# Altium schematic sheet Microcontroller.SchDoc(Microcontroller)
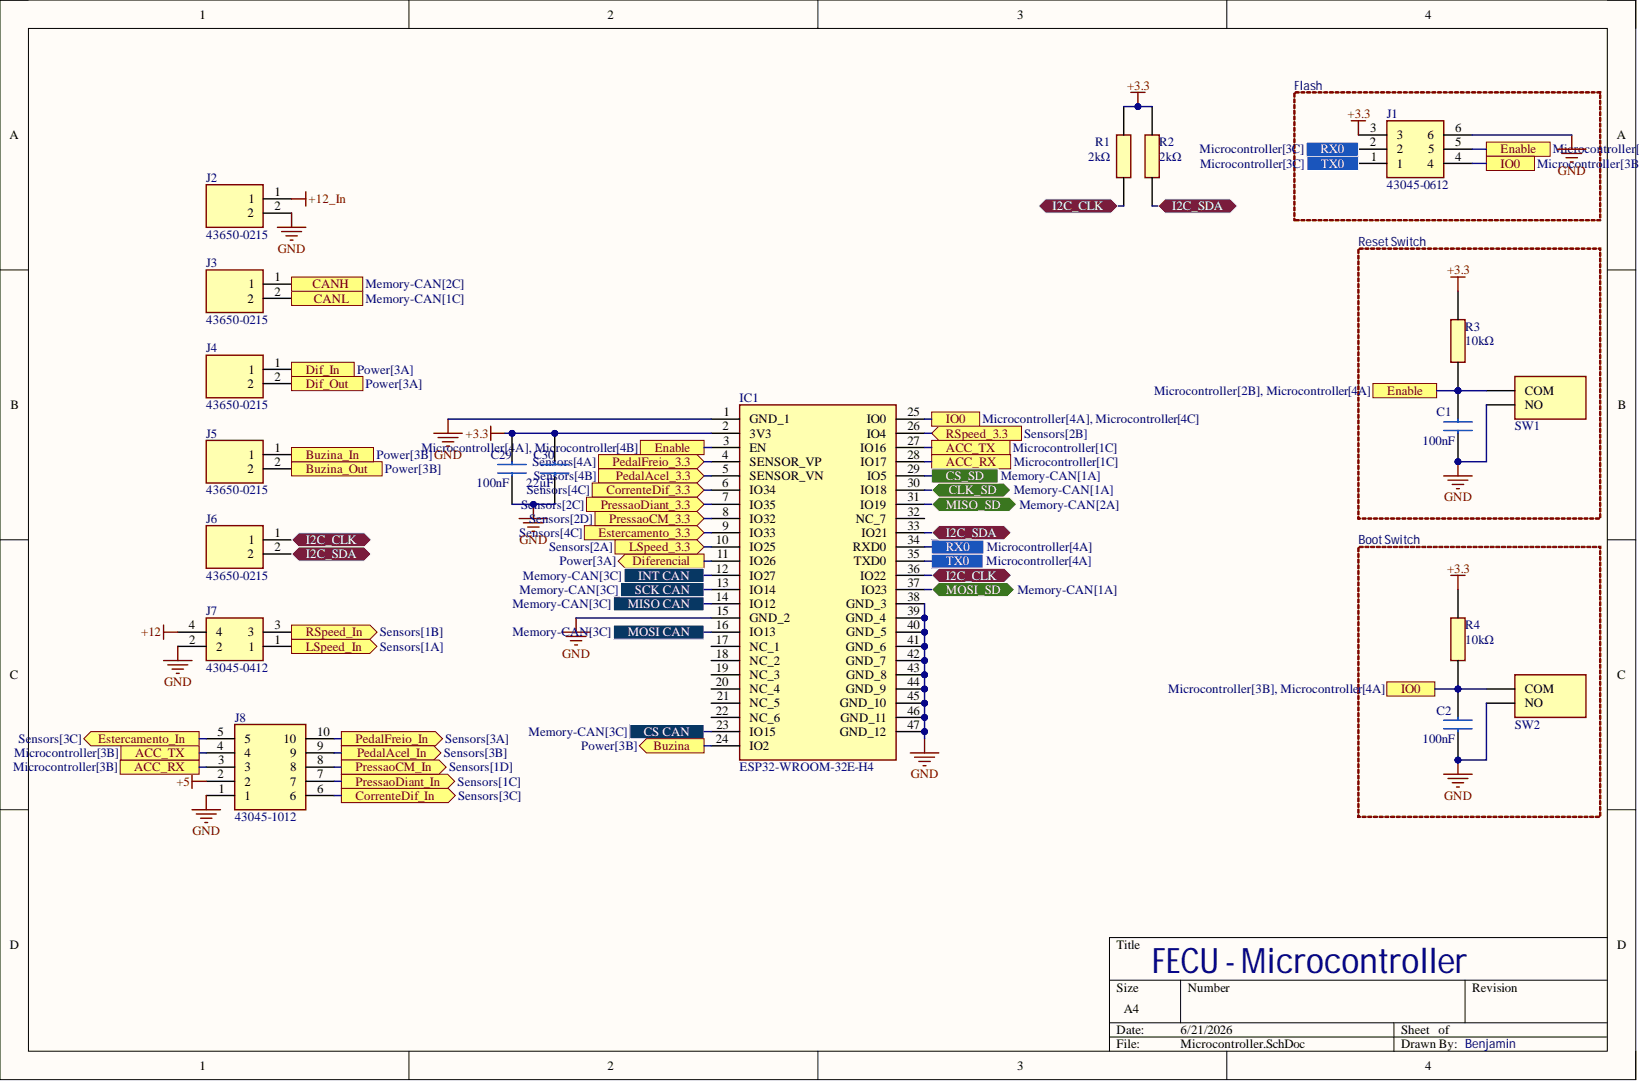
[diagram p0: Microcontroller.SchDoc]

## Parts
C1  100nF
C2  100nF
C29  100nF
C30  22µF
IC1  ESP32-WROOM-32E-H4
J1  43045-0612
J2  43650-0215
J3  43650-0215
J4  43650-0215
J5  43650-0215
J6  43650-0215
J7  43045-0412
J8  43045-1012
R1  2kΩ
R2  2kΩ
R3  10kΩ
R4  10kΩ
SW1  TS-1088R-02026  (value not drawn)
SW2  TS-1088R-02026  (value not drawn)

## Ports
ACC_RX, ACC_TX, Buzina, Buzina_In, Buzina_Out, CANH, CANL, CLK_SD, CorrenteDif_3.3, CorrenteDif_In, CS CAN, CS_SD, Dif_In, Dif_Out, Diferencial, Enable, Estercamento_3.3, Estercamento_In, I2C_CLK, I2C_SDA, INT CAN, IO0, LSpeed_3.3, LSpeed_In, MISO CAN, MISO_SD, MOSI CAN, MOSI_SD, PedalAcel_3.3, PedalAcel_In, PedalFreio_3.3, PedalFreio_In, PressaoCM_3.3, PressaoCM_In, PressaoDiant_3.3, PressaoDiant_In, RSpeed_3.3, RSpeed_In, RX0, SCK CAN, TX0

## Nets
+3.3: C29.2 C30.2 IC1.2(3V3) J1.3 R1.1 R2.1 R3.2 R4.2
+5: J8.2
+12: J7.4
+12_In: J2.1
GND: C1.1 C2.1 C29.1 C30.1 IC1.1(GND_1) IC1.15(GND_2) IC1.38(GND_3) IC1.39(GND_4) IC1.40(GND_5) IC1.41(GND_6) IC1.42(GND_7) IC1.43(GND_8) IC1.44(GND_9) IC1.45(GND_10) IC1.46(GND_11) IC1.47(GND_12) J1.6 J2.2 J7.2 J8.1 SW1.2 SW2.2
ACC_RX: IC1.28(IO17) J8.3 ; port ACC_RX
ACC_TX: IC1.27(IO16) J8.4 ; port ACC_TX
Buzina: IC1.24(IO2) ; port Buzina
Buzina_In: J5.1 ; port Buzina_In
Buzina_Out: J5.2 ; port Buzina_Out
CANH: J3.1 ; port CANH
CANL: J3.2 ; port CANL
CLK_SD: IC1.30(IO18) ; port CLK_SD
CorrenteDif_3.3: IC1.6(IO34) ; port CorrenteDif_3.3
CorrenteDif_In: J8.6 ; port CorrenteDif_In
CS CAN: IC1.23(IO15) ; port CS CAN
CS_SD: IC1.29(IO5) ; port CS_SD
Dif_In: J4.1 ; port Dif_In
Dif_Out: J4.2 ; port Dif_Out
Diferencial: IC1.11(IO26) ; port Diferencial
Enable: C1.2 IC1.3(EN) J1.5 R3.1 SW1.1 ; port Enable
Estercamento_3.3: IC1.9(IO33) ; port Estercamento_3.3
Estercamento_In: J8.5 ; port Estercamento_In
I2C_CLK: IC1.36(IO22) J6.1 R1.2 ; port I2C_CLK
I2C_SDA: IC1.33(IO21) J6.2 R2.2 ; port I2C_SDA
INT CAN: IC1.12(IO27) ; port INT CAN
IO0: C2.2 IC1.25(IO0) J1.4 R4.1 SW2.1 ; port IO0
LSpeed_3.3: IC1.10(IO25) ; port LSpeed_3.3
LSpeed_In: J7.1 ; port LSpeed_In
MISO CAN: IC1.14(IO12) ; port MISO CAN
MISO_SD: IC1.31(IO19) ; port MISO_SD
MOSI CAN: IC1.16(IO13) ; port MOSI CAN
MOSI_SD: IC1.37(IO23) ; port MOSI_SD
PedalAcel_3.3: IC1.5(SENSOR_VN) ; port PedalAcel_3.3
PedalAcel_In: J8.9 ; port PedalAcel_In
PedalFreio_3.3: IC1.4(SENSOR_VP) ; port PedalFreio_3.3
PedalFreio_In: J8.10 ; port PedalFreio_In
PressaoCM_3.3: IC1.8(IO32) ; port PressaoCM_3.3
PressaoCM_In: J8.8 ; port PressaoCM_In
PressaoDiant_3.3: IC1.7(IO35) ; port PressaoDiant_3.3
PressaoDiant_In: J8.7 ; port PressaoDiant_In
RSpeed_3.3: IC1.26(IO4) ; port RSpeed_3.3
RSpeed_In: J7.3 ; port RSpeed_In
RX0: IC1.34(RXD0) J1.2 ; port RX0
SCK CAN: IC1.13(IO14) ; port SCK CAN
TX0: IC1.35(TXD0) J1.1 ; port TX0

Not connected: IC1 17(NC_1) 18(NC_2) 19(NC_3) 20(NC_4) 21(NC_5) 22(NC_6) 32(NC_7)

## Library data of the parts
C1 C2 C29 = CL21B104KBCNNNC: Capacitor; Manufacturer_Name: SAMSUNG; Arrow Part Number: CL21B104KBCNNNC; Component Kind: Standard; Datasheet Link: https://datasheet.datasheetarchive.com/originals/distributors/Datasheets_SAMA/86a3eaf0882763ef32124d5901f9b833.pdf; Height: 0.95mm; Manufacturer_Part_Number: CL21B104KBCNNNC; Mouser Part Number: 187-CL21B104KBCNNNC; Mouser Price/Stock: https://www.mouser.co.uk/ProductDetail/Samsung-Electro-Mechanics/CL21B104KBCNNNC?qs=349EhDEZ59pEfpnm5yBEmQ%3D%3D
  C1 C2: Arrow Price/Stock: https://www.arrow.com/en/products/cl21b104kbcnnnc/samsung-electro-mechanics?region=europe
  C29: Arrow Price/Stock: https://www.arrow.com/en/products/cl21b104kbcnnnc/samsung-electro-mechanics?utm_currency=USD&region=nac
C30 = CL21A226MAYNNNE: Capacitor; Manufacturer_Name: SAMSUNG; Arrow Part Number: CL21A226MAYNNNE; Arrow Price/Stock: https://www.arrow.com/en/products/cl21a226maynnne/samsung-electro-mechanics?utm_currency=USD&region=europe; Component Kind: Standard; Datasheet Link: http://product.samsungsem.com/mlcc/CL21A226MAYNNN.do; Height: 1.45mm; Manufacturer_Part_Number: CL21A226MAYNNNE; Mouser Part Number: 187-CL21A226MAYNNNE; Mouser Price/Stock: https://www.mouser.co.uk/ProductDetail/Samsung-Electro-Mechanics/CL21A226MAYNNNE?qs=xZ%2FP%252Ba9zWqY%2FVvCfXjMhqg%3D%3D
IC1 = ESP32-WROOM-32E-H4: Integrated Circuit; Manufacturer_Name: Espressif Systems; Component Kind: Standard; Datasheet Link: https://www.espressif.com/sites/default/files/documentation/esp32-wroom-32e_esp32-wroom-32ue_datasheet_en.pdf; Height: 3.25mm; Manufacturer_Part_Number: ESP32-WROOM-32E-H4; Mouser Part Number: 356-ESP32WRM320EH3PS; Mouser Price/Stock: https://www.mouser.co.uk/ProductDetail/Espressif-Systems/ESP32-WROOM-32E-H4?qs=Li%252BoUPsLEnuUxeqGSCz%252BcA%3D%3D
J1 = 43045-0612: Connector; Manufacturer_Name: Molex; Arrow Part Number: 43045-0612; Arrow Price/Stock: https://www.arrow.com/en/products/43045-0612/molex?region=nac; Component Kind: Standard; Datasheet Link: https://www.molex.com/pdm_docs/sd/430450612_sd.pdf; Height: 9.91mm; Manufacturer_Part_Number: 43045-0612; Mouser Part Number: 538-43045-0612; Mouser Price/Stock: https://www.mouser.co.uk/ProductDetail/Molex/43045-0612?qs=KC2ywxza1kqWo9LLJ5Lh3w%3D%3D
J2-J6 = 43650-0215: Connector; Manufacturer_Name: Molex; Component Kind: Standard; Datasheet Link: https://www.molex.com/pdm_docs/sd/436500215_sd.pdf; Height: 9.9mm; Library Name: SamacSys.SchLib; Manufacturer_Part_Number: 43650-0215; Mouser Part Number: 538-43650-0215; Mouser Price/Stock: https://www.mouser.co.uk/ProductDetail/Molex/43650-0215?qs=AplfTeSvkkDe0NAwMnlPNw%3D%3D
J7 = 43045-0412: Connector; Manufacturer_Name: Molex; Arrow Part Number: 43045-0412; Arrow Price/Stock: https://www.arrow.com/en/products/43045-0412/molex?utm_currency=USD&region=nac; Component Kind: Standard; Datasheet Link: https://www.molex.com/pdm_docs/sd/430450612_sd.pdf; Height: 9.91mm; Manufacturer_Part_Number: 43045-0412; Mouser Part Number: 538-43045-0412; Mouser Price/Stock: https://www.mouser.co.uk/ProductDetail/Molex/43045-0412?qs=UeCeOHRHQebPBbEMDLocEw%3D%3D
J8 = 43045-1012: Connector; Manufacturer_Name: Molex; Component Kind: Standard; Datasheet Link: https://www.molex.com/pdm_docs/sd/430450612_sd.pdf; Height: 9.91mm; Library Name: SamacSys.SchLib; Manufacturer_Part_Number: 43045-1012; Mouser Part Number: 538-43045-1012; Mouser Price/Stock: https://www.mouser.co.uk/ProductDetail/Molex/43045-1012?qs=KC2ywxza1koTZPAgAiHw3w%3D%3D
R1 R2 = 0805W8F2001T5E: Resistor; Manufacturer_Name: UNI-ROYAL(Uniroyal Elec); Component Kind: Standard; Datasheet Link: https://datasheet.lcsc.com/lcsc/2206010200_UNI-ROYAL-Uniroyal-Elec-0805W8F2001T5E_C17604.pdf; Height: 0.65mm; Manufacturer_Part_Number: 0805W8F2001T5E
R3 R4 = RC0805FR-0710KL: Resistor; Manufacturer_Name: YAGEO; Arrow Part Number: RC0805FR-0710KL; Arrow Price/Stock: https://www.arrow.com/en/products/rc0805fr-0710kl/yageo?utm_currency=USD&region=europe; Component Kind: Standard; Datasheet Link: https://www.yageo.com/upload/media/product/productsearch/datasheet/rchip/PYu-RC_Group_51_RoHS_L_11.pdf; Height: 0.6mm; Manufacturer_Part_Number: RC0805FR-0710KL; Mouser Part Number: 603-RC0805FR-0710KL; Mouser Price/Stock: https://www.mouser.co.uk/ProductDetail/YAGEO/RC0805FR-0710KL?qs=7bY8808IzHq6vrU3BeiiYw%3D%3D
SW1 SW2 = TS-1088R-02026: Switch; Manufacturer_Name: XUNPU; Component Kind: Standard; Datasheet Link: https://datasheet.lcsc.com/szlcsc/1912111437_XUNPU-TS-1088R-02026_C455280.pdf; Manufacturer_Part_Number: TS-1088R-02026
